# Altium schematic sheet lv_io_main_connector.SchDoc
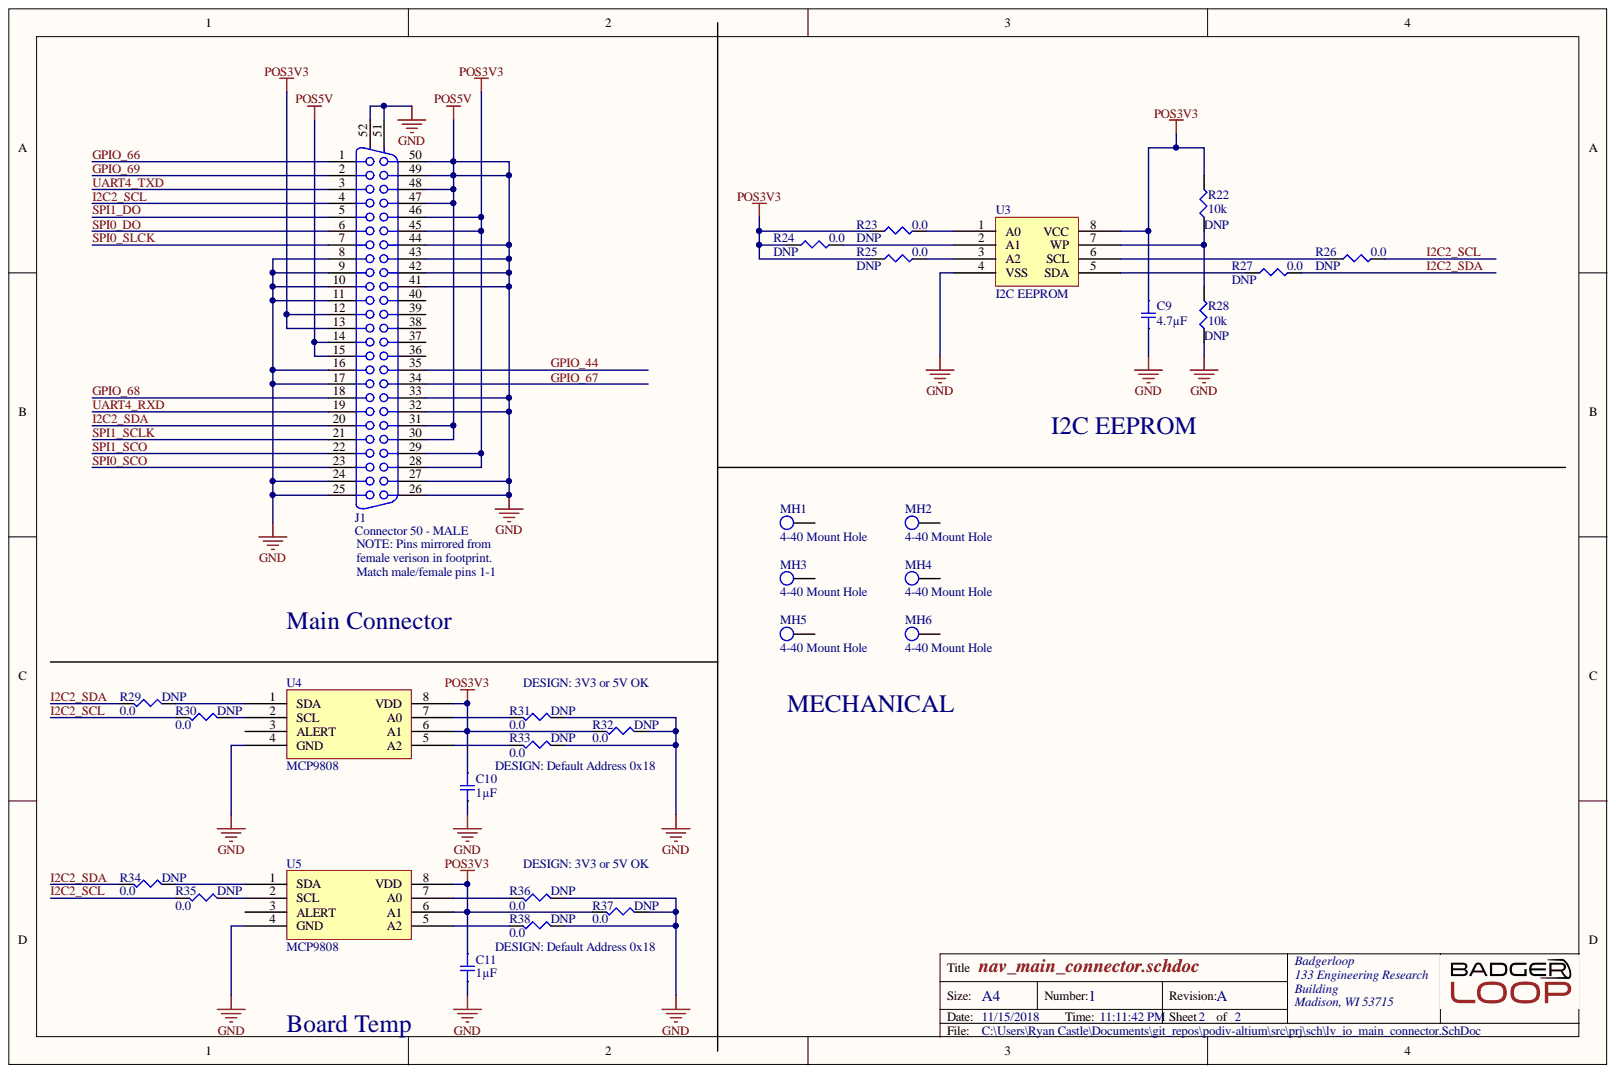
[diagram p0: lv_io_main_connector.SchDoc]

## Parts
C9  4.7µF  [SMD-0805C]
C10  1µF  [SMD-0805C]
C11  1µF  [SMD-0805C]
J1  Connector 50 - MALE  [050DSUB1.27-H96-MALE]
MH1  4-40 Mount Hole  [MH]
MH2  4-40 Mount Hole  [MH]
MH3  4-40 Mount Hole  [MH]
MH4  4-40 Mount Hole  [MH]
MH5  4-40 Mount Hole  [MH]
MH6  4-40 Mount Hole  [MH]
R22  10k  [SMD-0402-RES]
R23  0.0  [SMD-0402-RES]
R24  0.0  [SMD-0402-RES]
R25  0.0  [SMD-0402-RES]
R26  0.0  [SMD-0402-RES]
R27  0.0  [SMD-0402-RES]
R28  10k  [SMD-0402-RES]
R29  0.0  [SMD-0402-RES]
R30  0.0  [SMD-0402-RES]
R31  0.0  [SMD-0402-RES]
R32  0.0  [SMD-0402-RES]
R33  0.0  [SMD-0402-RES]
R34  0.0  [SMD-0402-RES]
R35  0.0  [SMD-0402-RES]
R36  0.0  [SMD-0402-RES]
R37  0.0  [SMD-0402-RES]
R38  0.0  [SMD-0402-RES]
U3  I2C EEPROM  [SOIC127P600X175-8N]
U4  MCP9808  [TSOP65P490X110-8N]
U5  MCP9808  [TSOP65P490X110-8N]

## Nets
GND: C9.2 C10.2 C11.2 J1.8 J1.9 J1.10 J1.11 J1.14 J1.15 J1.16 J1.17 J1.24 J1.25 J1.26 J1.27 J1.30 J1.31 J1.32 J1.33 J1.41 J1.42 J1.43 J1.44 J1.47 J1.48 J1.49 J1.50 J1.51 J1.52 R28.1 R31.1 R32.1 R33.1 R36.1 R37.1 R38.1 U3.4(VSS) U4.4(GND) U5.4(GND)
POS3V3: C9.1 C10.1 C11.1 J1.12 J1.13 J1.28 J1.29 J1.45 J1.46 R22.2 R23.1 R24.1 R25.1 R32.2 R37.2 U3.8(VCC) U4.6(A1) U4.8(VDD) U5.6(A1) U5.8(VDD)
GPIO_44: J1.35
GPIO_66: J1.1
GPIO_67: J1.34
GPIO_68: J1.18
GPIO_69: J1.2
I2C2_SCL: J1.4 R26.2 R30.2 R35.2
I2C2_SDA: J1.20 R27.2 R29.2 R34.2
SPI0_DO: J1.6
SPI0_SCO: J1.23
SPI0_SLCK: J1.7
SPI1_DO: J1.5
SPI1_SCLK: J1.21
SPI1_SCO: J1.22
UART4_RXD: J1.19
UART4_TXD: J1.3
NetR22_1: R22.1 R28.2 U3.7(WP)
NetR23_2: R23.2 U3.1(A0)
NetR24_2: R24.2 U3.2(A1)
NetR25_2: R25.2 U3.3(A2)
NetR26_1: R26.1 U3.6(SCL)
NetR27_1: R27.1 U3.5(SDA)
NetR29_1: R29.1 U4.1(SDA)
NetR30_1: R30.1 U4.2(SCL)
NetR31_2: R31.2 U4.7(A0)
NetR33_2: R33.2 U4.5(A2)
NetR34_1: R34.1 U5.1(SDA)
NetR35_1: R35.1 U5.2(SCL)
NetR36_2: R36.2 U5.7(A0)
NetR38_2: R38.2 U5.5(A2)

Not connected: J1 36 37 38 39 40; MH1 46; MH2 46; MH3 46; MH4 46; MH5 46; MH6 46; U4 3(ALERT); U5 3(ALERT)

## Library data of the parts
C9 = GCJ21BR71C475KA01L: CAP CER 4.7UF 16V X7R 0805; Manufacturer: Murata Electronics North America; @ qty: 0; Minimum Quantity: 1; Tolerance: ±10%; Unit Price (USD): 0.44; Applications: Automotive, Boardflex Sensitive; Component Kind: Standard; Datasheets: http://www.murata.com/~/media/webrenewal/support/library/catalog/products/capacitor/mlcc/c03e.ashx; Digi-Key Part Number: 490-10557-1-ND; Factory Stock: 0; Features: Soft Termination; Height - Seated (Max): -; Image: //media.digikey.com/Renders/Murata%20Renders/0805%20(2012%20Metric)%20.85mm.jpg; Lead Spacing: -; Lead Style: -; Library Name: capacitor.SchLib; Mounting Type: Surface Mount, MLCC; Operating Temperature: -55°C ~ 125°C; Package / Case: 0805 (2012 Metric); Packaging: Cut Tape (CT); Part Number: GCJ21BR71C475KA01L; Part Status: Active; Quantity Available: 53217; Ratings: AEC-Q200; Series: GCJ; Size / Dimension: 0.079" L x 0.049" W (2.00mm x 1.25mm); Temperature Coefficient: X7R; Thickness (Max): 0.057" (1.45mm); Value: 4.7µF; Voltage: 16V
C10 C11 = GCJ21BR71E105KA12L: CAP CER 1UF 25V X7R 0805; Manufacturer: Murata Electronics North America; @ qty: 0; Minimum Quantity: 1; Tolerance: ±10%; Unit Price (USD): 0.36; Applications: Automotive, Boardflex Sensitive; Component Kind: Standard; Datasheets: http://www.murata.com/~/media/webrenewal/support/library/catalog/products/capacitor/mlcc/c03e.ashx; Digi-Key Part Number: 490-5802-1-ND; Factory Stock: 0; Features: Soft Termination; Height - Seated (Max): -; Image: //media.digikey.com/Renders/Murata%20Renders/0805%20(2012%20Metric)%20.85mm.jpg; Lead Spacing: -; Lead Style: -; Library Name: capacitor.SchLib; Mounting Type: Surface Mount, MLCC; Operating Temperature: -55°C ~ 125°C; Package / Case: 0805 (2012 Metric); Packaging: Cut Tape (CT); Part Number: GCJ21BR71E105KA12L; Part Status: Active; Quantity Available: 15950; Ratings: AEC-Q200; Series: GCJ; Size / Dimension: 0.079" L x 0.049" W (2.00mm x 1.25mm); Temperature Coefficient: X7R; Thickness (Max): 0.057" (1.45mm); Value: 1µF; Voltage: 25V
J1 = Connector 50 - MALE: Receptacle Header Assemby; Manufacturer: HARTING; Manufacturer P/N: 09665627811; Component Kind: Standard; Digikey Link: https://www.digikey.com/product-detail/en/harting/09665627811/1195-5057-ND/4322749; Digikey P/N: 1195-5057-ND; Library Name: temp.SchLib
R22 R28 = ERJ-2GEJ103X: RES SMD 10K OHM 5% 1/10W 0402; Manufacturer: Panasonic Electronic Components; @ qty: 1500; Factory Stock: 0; Minimum Quantity: 1; Power (Watts): 0.1W, 1/10W; Temperature Coefficient: ±200ppm/°C; Unit Price (USD): 0.00350; Component Kind: Standard; Composition: Thick Film; Datasheets: http://industrial.panasonic.com/www-cgi/jvcr13pz.cgi?E+PZ+3+AOA0001+ERJ2GEJ103X+7+WW; Digi-Key Part Number: P10KJCT-ND; DNP: DNP; Failure Rate: -; Features: Automotive AEC-Q200; Height - Seated (Max): 0.016" (0.40mm); Image: //media.digikey.com/Photos/Panasonic%20Photos/ERJ-PA2-Series.jpg; Library Name: resistor.SchLib; Number of Terminations: 2; Operating Temperature: -55°C ~ 155°C; Package / Case: 0402 (1005 Metric); Packaging: Cut Tape (CT); Part Number: ERJ-2GEJ103X; Part Status: Active; Quantity Available: 2560215; Series: ERJ; Size / Dimension: 0.039" L x 0.020" W (1.00mm x 0.50mm); Supplier Device Package: 0402; Tolerance: ±5%; Value: 10k
R23-R27 R29-R38 = ERJ-2GE0R00X: RES SMD 0.0OHM JUMPER 1/10W 0402; Manufacturer: Panasonic Electronic Components; @ qty: 1500; Factory Stock: 0; Minimum Quantity: 1; Power (Watts): 0.1W, 1/10W; Unit Price (USD): 0.00384; Component Kind: Standard; Composition: Thick Film; Datasheets: http://industrial.panasonic.com/www-cgi/jvcr13pz.cgi?E+PZ+3+AOA0001+ERJ2GE0R00X+7+WW; Digi-Key Part Number: P0.0JCT-ND; DNP: DNP; Failure Rate: -; Features: Automotive AEC-Q200; Height - Seated (Max): 0.016" (0.40mm); Image: //media.digikey.com/Photos/Panasonic%20Photos/ERJ-PA2-Series.jpg; Library Name: resistor.SchLib; Number of Terminations: 2; Operating Temperature: -55°C ~ 155°C; Package / Case: 0402 (1005 Metric); Packaging: Cut Tape (CT); Part Number: ERJ-2GE0R00X; Part Status: Active; Quantity Available: 3152483; Series: ERJ; Size / Dimension: 0.039" L x 0.020" W (1.00mm x 0.50mm); Supplier Device Package: 0402; Temperature Coefficient: -; Tolerance: Jumper; Value: 0.0
U3 = I2C EEPROM: IC EEPROM 32K I2C 1MHZ 8SOIC; Manufacturer: ON Semi; Manufacturer P/N: CAT24C32WI-GT3; Component Kind: Standard; Digikey P/N: CAT24C32WI-Gt3CT-ND; Library Name: temp.SchLib
U4 U5 = MCP9808: SENSOR DIGITAL -40C-125C 8MSOP; Manufacturer: Microchip; Component Kind: Standard; Datasheet: http://ww1.microchip.com/downloads/en/DeviceDoc/25095A.pdf; Digikey Link: https://www.digikey.com/product-detail/en/microchip-technology/MCP9808-E-MS/MCP9808-E-MS-ND/2802083; Digikey P/N: MCP9808-E/MS-ND; Library Name: temp.SchLib; Manufacturer P/N: MCP9808
MH1-MH6 = 4-40 Mount Hole: Component Kind: Standard; Library Name: misc.SchLib
